# Altium schematic sheet Sheet3.SchDoc
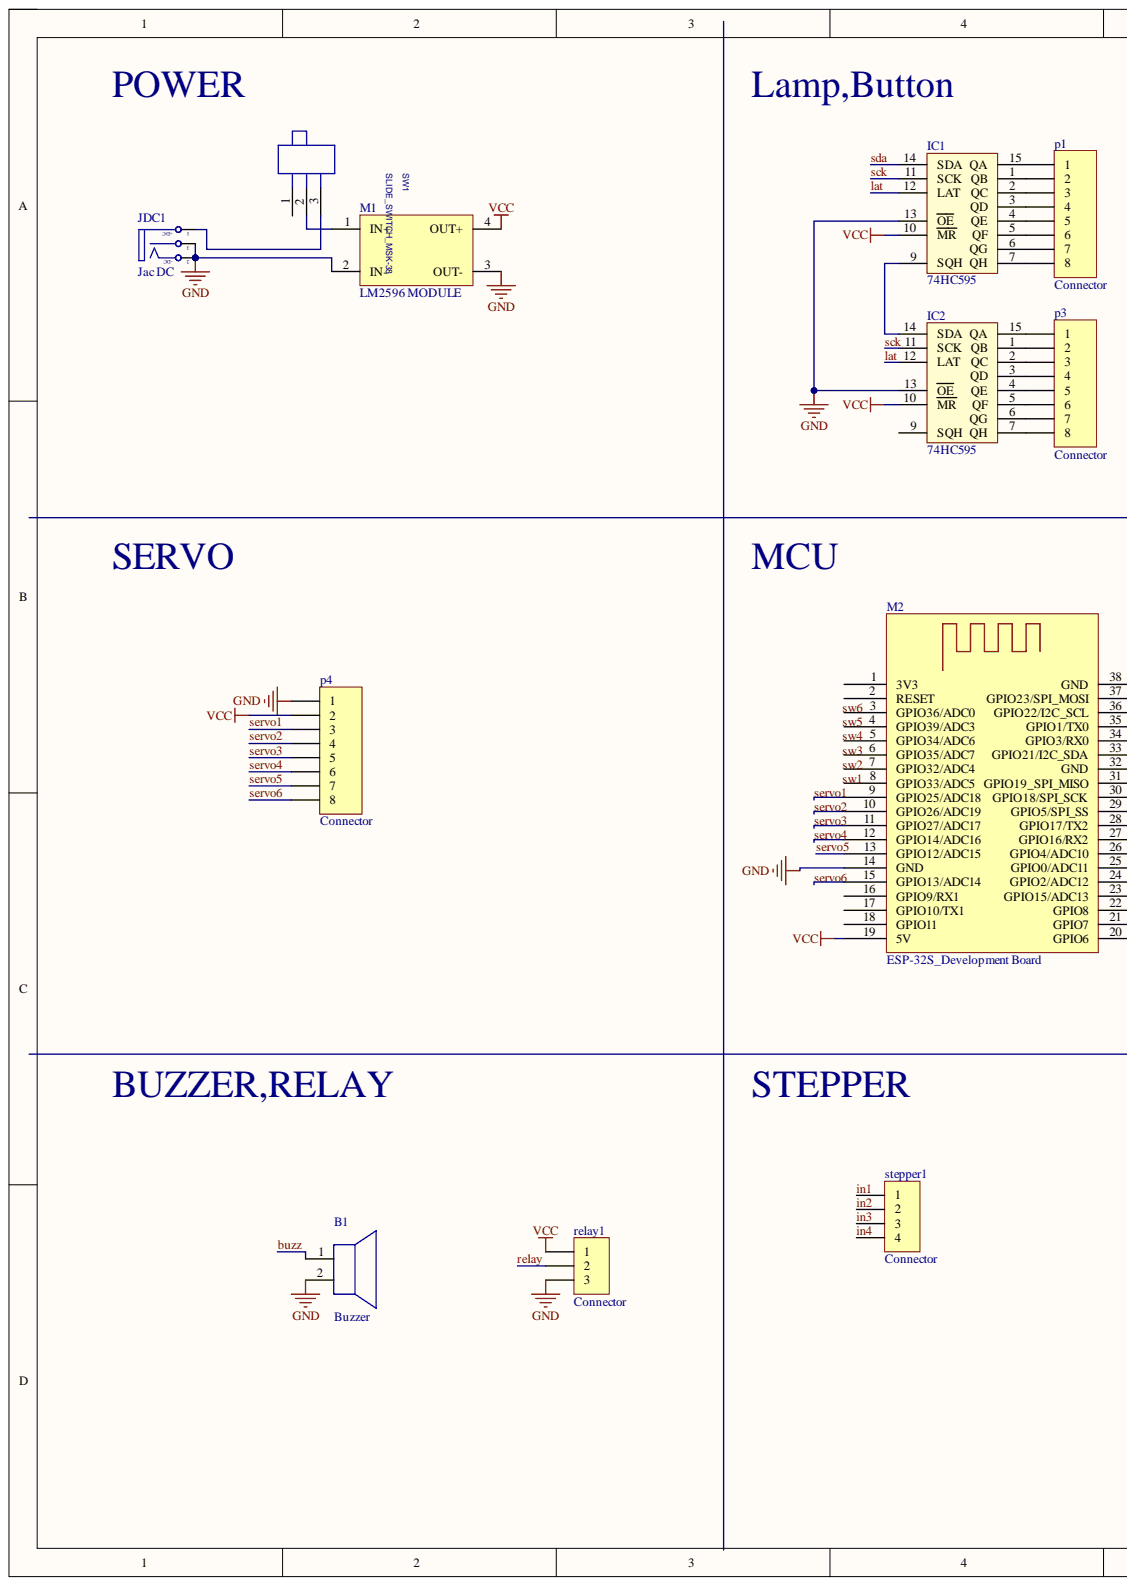
[diagram p0: Sheet3.SchDoc, left]
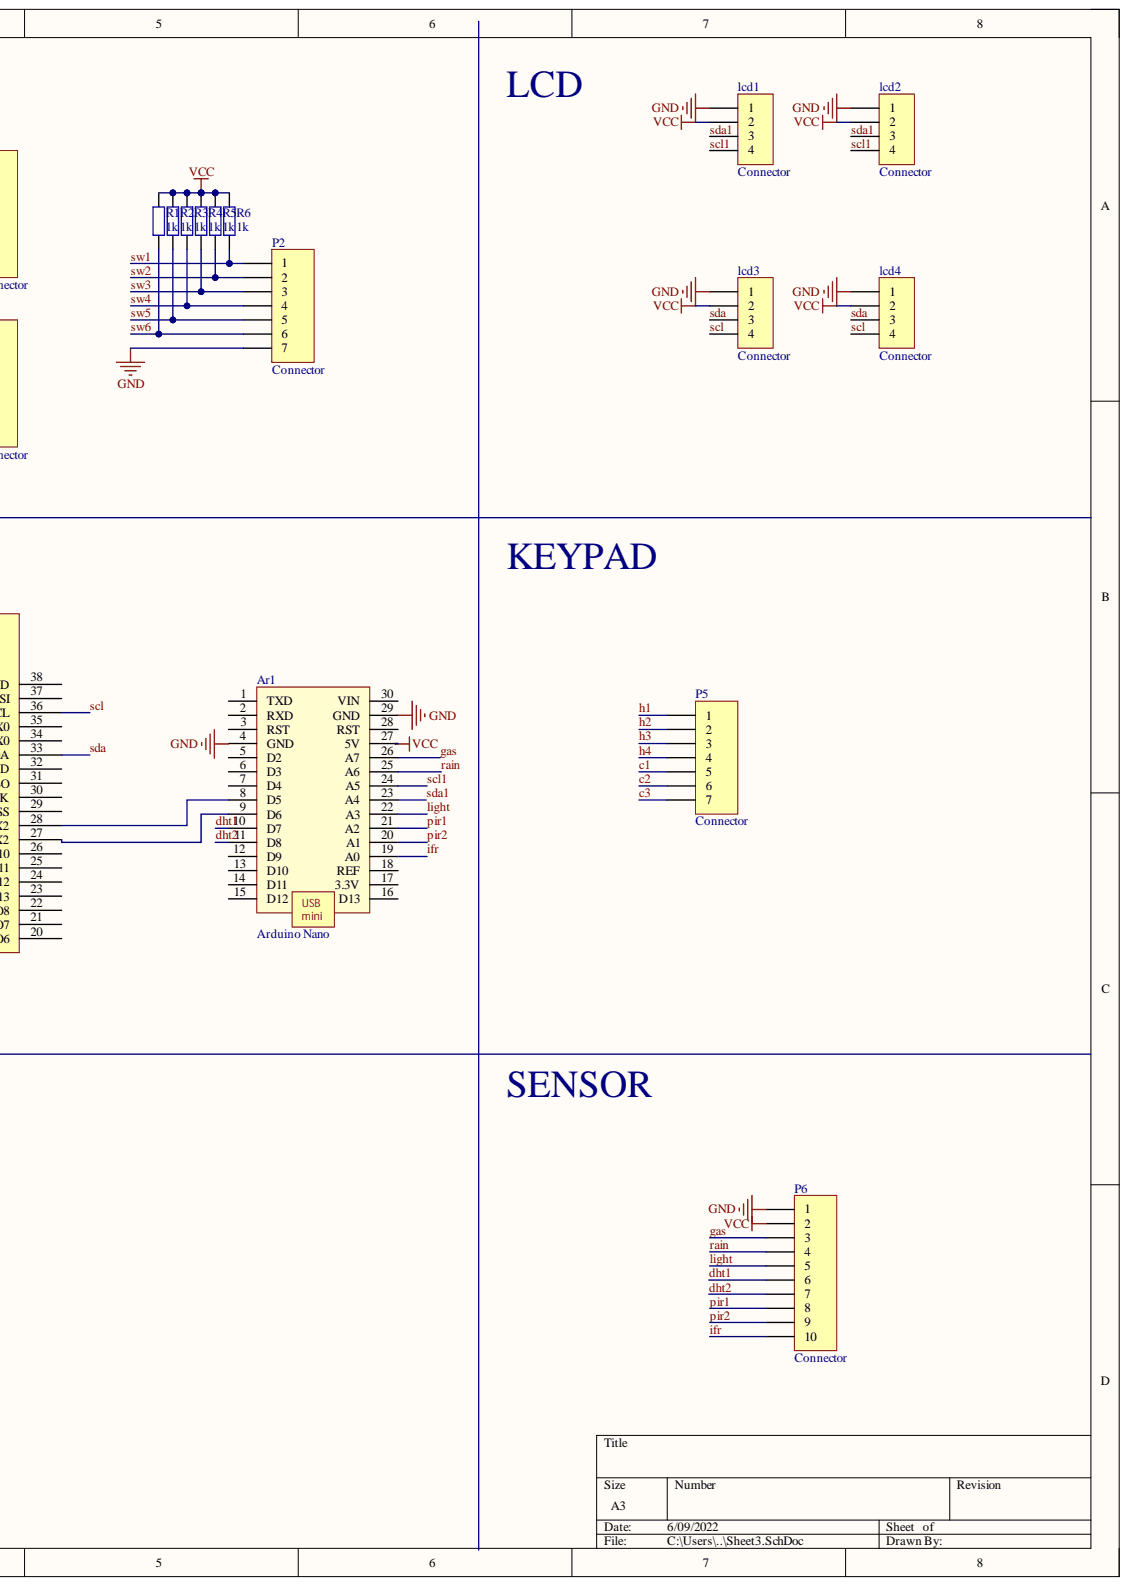
[diagram p1: Sheet3.SchDoc, right]

## Parts
Ar1  Arduino Nano  [Arduino_Nano]
B1  Buzzer  [Buzzer]
IC1  74HC595  [DIP16/W0.3]
IC2  74HC595  [DIP16/W0.3]
JDC1  Jac DC  [DC-IN]
lcd1  Connector  [FFC/SM1.0-2H4]
lcd2  Connector  [FFC/SM1.0-2H4]
lcd3  Connector  [FFC/SM1.0-2H4]
lcd4  Connector  [FFC/SM1.0-2H4]
M1  LM2596 MODULE  [LM2596_MODULE]
M2  ESP-32S_Development Board  [ESP32 NodeMCU]
p1  Connector  [JST-XH_B8B-XH-A]
P2  Connector  [JST-XH_B7B-XH-A]
p3  Connector  [JST-XH_B8B-XH-A]
p4  Connector  [JST-XH_B8B-XH-A]
P5  Connector  [JST-XH_B7B-XH-A]
P6  Connector  [HDR1X10_SMD]
R1  1k  [tro smd 0805]
R2  1k  [tro smd 0805]
R3  1k  [tro smd 0805]
R4  1k  [tro smd 0805]
R5  1k  [tro smd 0805]
R6  1k  [tro smd 0805]
relay1  Connector  [JST-XH_B3B-XH-A]
stepper1  Connector  [FFC/SM1.0-2H4]
SW1  SLIDE_SWITCH_MSK-38  [SW6_MSK-38]

## Nets
GND: Ar1.29(GND) B1.2 IC1.8 IC1.13(OE) IC2.8 IC2.13(OE) JDC1.2 JDC1.3 lcd1.1 lcd2.1 lcd3.1 lcd4.1 M1.2(IN-) M1.3(OUT-) M2.14(GND) P2.7 p4.1 P6.1 relay1.3
VCC: Ar1.27(5V) IC1.10(MR) IC1.16 IC2.10(MR) IC2.16 lcd1.2 lcd2.2 lcd3.2 lcd4.2 M1.4(OUT+) M2.19(5V) p4.2 P6.2 R1.2 R2.2 R3.2 R4.2 R5.2 R6.2 relay1.1
buzz: B1.1
c1: P5.5
c2: P5.6
c3: P5.7
dht1: Ar1.10(D7) P6.6
dht2: Ar1.11(D8) P6.7
gas: Ar1.26(A7) P6.3
h1: P5.1
h2: P5.2
h3: P5.3
h4: P5.4
ifr: Ar1.19(A0) P6.10
in1: stepper1.1
in2: stepper1.2
in3: stepper1.3
in4: stepper1.4
lat: IC1.12(LAT) IC2.12(LAT)
light: Ar1.22(A3) P6.5
pir1: Ar1.21(A2) P6.8
pir2: Ar1.20(A1) P6.9
rain: Ar1.25(A6) P6.4
relay: relay1.2
sck: IC1.11(SCK) IC2.11(SCK)
scl: lcd3.4 lcd4.4 M2.36(GPIO22/I2C_SCL)
scl1: Ar1.24(A5) lcd1.4 lcd2.4
sda: IC1.14(SDA) lcd3.3 lcd4.3 M2.33(GPIO21/I2C_SDA)
sda1: Ar1.23(A4) lcd1.3 lcd2.3
servo1: M2.9(GPIO25/ADC18) p4.3
servo2: M2.10(GPIO26/ADC19) p4.4
servo3: M2.11(GPIO27/ADC17) p4.5
servo4: M2.12(GPIO14/ADC16) p4.6
servo5: M2.13(GPIO12/ADC15) p4.7
servo6: M2.15(GPIO13/ADC14) p4.8
sw1: P2.1 R6.1
sw2: P2.2 R5.1
sw3: P2.3 R4.1
sw4: P2.4 R3.1
sw5: P2.5 R2.1
sw6: P2.6 R1.1
NetAr1_8: Ar1.8(D5) M2.28(GPIO17/TX2)
NetAr1_9: Ar1.9(D6) M2.27(GPIO16/RX2)
NetIC1_1: IC1.1(QB) p1.2
NetIC1_2: IC1.2(QC) p1.3
NetIC1_3: IC1.3(QD) p1.4
NetIC1_4: IC1.4(QE) p1.5
NetIC1_5: IC1.5(QF) p1.6
NetIC1_6: IC1.6(QG) p1.7
NetIC1_7: IC1.7(QH) p1.8
NetIC1_9: IC1.9(SQH) IC2.14(SDA)
NetIC1_15: IC1.15(QA) p1.1
NetIC2_1: IC2.1(QB) p3.2
NetIC2_2: IC2.2(QC) p3.3
NetIC2_3: IC2.3(QD) p3.4
NetIC2_4: IC2.4(QE) p3.5
NetIC2_5: IC2.5(QF) p3.6
NetIC2_6: IC2.6(QG) p3.7
NetIC2_7: IC2.7(QH) p3.8
NetIC2_15: IC2.15(QA) p3.1
NetJDC1_1: JDC1.1 SW1.3
NetM1_1: M1.1(IN+) SW1.2

Not connected: Ar1 1(TXD) 2(RXD) 3(RST) 4(GND) 5(D2) 6(D3) 7(D4) 12(D9) 13(D10) 14(D11) 15(D12) 16(D13) 17(3.3V) 18(REF) 28(RST) 30(VIN); IC2 9(SQH); M2 1(3V3) 2(RESET) 3(GPIO36/ADC0) 4(GPIO39/ADC3) 5(GPIO34/ADC6) 6(GPIO35/ADC7) 7(GPIO32/ADC4) 8(GPIO33/ADC5) 16(GPIO9/RX1) 17(GPIO10/TX1) 18(GPIO11) 20(GPIO6) 21(GPIO7) 22(GPIO8) 23(GPIO15/ADC13) 24(GPIO2/ADC12) 25(GPIO0/ADC11) 26(GPIO4/ADC10) 29(GPIO5/SPI_SS) 30(GPIO18/SPI_SCK) 31(GPIO19_SPI_MISO) 32(GND) 34(GPIO3/RX0) 35(GPIO1/TX0) 37(GPIO23/SPI_MOSI) 38(GND); SW1 1

## Library data of the parts
Ar1 = Arduino_Nano: Component Kind: Standard; Library Name: DaoTakIsaac.SchLib
IC1 IC2 = 74595: 8 Bit Serial input - Parallel Output; Component Kind: Standard; Value: 74HC595; Library Name: hphonglib.SchLib
JDC1 = DCIN: Jac DC input; Component Kind: Standard; Value: Jac DC; Library Name: MinhTien_SCHLib.SchLib
lcd1-lcd4 stepper1 = Connector_4pin: Component Kind: Standard; Library Name: MinhTien_SCHLib.SchLib
M1 = LM2596_MODULE: Component Kind: Standard; Description: LM2596 MODULE; Library Name: Indruino.SchLib
M2 = WIFI_ESP-32S_Development Board: ESP32 NodeMCU LuaNode32 38 Pins; Component Kind: Standard; Library Name: MinhTien_SCHLib.SchLib
p1 p3 p4 = Connector_8pin: Component Kind: Standard; Library Name: MinhTien_SCHLib.SchLib
P2 P5 = Connector_7pin: Component Kind: Standard; Library Name: MinhTien_SCHLib.SchLib
P6 = Connector_10pin: Component Kind: Standard; Library Name: MinhTien_SCHLib.SchLib
R1-R6 = Tro 0805 1% 1k: Dán; Don_Vi: Con; Ma_Hang: MH005468; So_Chan: 2; Ten_Linh_Kien: Trở 0805 1% 1k; Chung_Loai: Dán; Component Kind: Standard; Library Name: Altium_Lib_by_KhueNguyenCreator.IntLib
relay1 = Connector_3pin: Component Kind: Standard; Library Name: MinhTien_SCHLib.SchLib
SW1 = SLIDE_SWITCH_MSK-38: SWITCH SLIDE SPDT 0.5A; Manufacturer: E-Switch; Manufacturer Part Number: 500SSP1S1M6QEA; Part Number: 021-SW-08; Allegro PCB Footprint: SLIDE_SW_2; Rating: 120V; Component Kind: Standard; Distributor: Digi-Key; Distributor Part Number: EG2478-ND; Layout PCB Footprint: SLIDE_SW_2; Part Type: Switches; Price: 3.1; Library Name: MinhTien_SCHLib.SchLib
B1 = Buzzer: Component Kind: Standard; Library Name: MinhTien_SCHLib.SchLib
